# Altium schematic sheet 04_SMPS.SchDoc(04_SMPS)
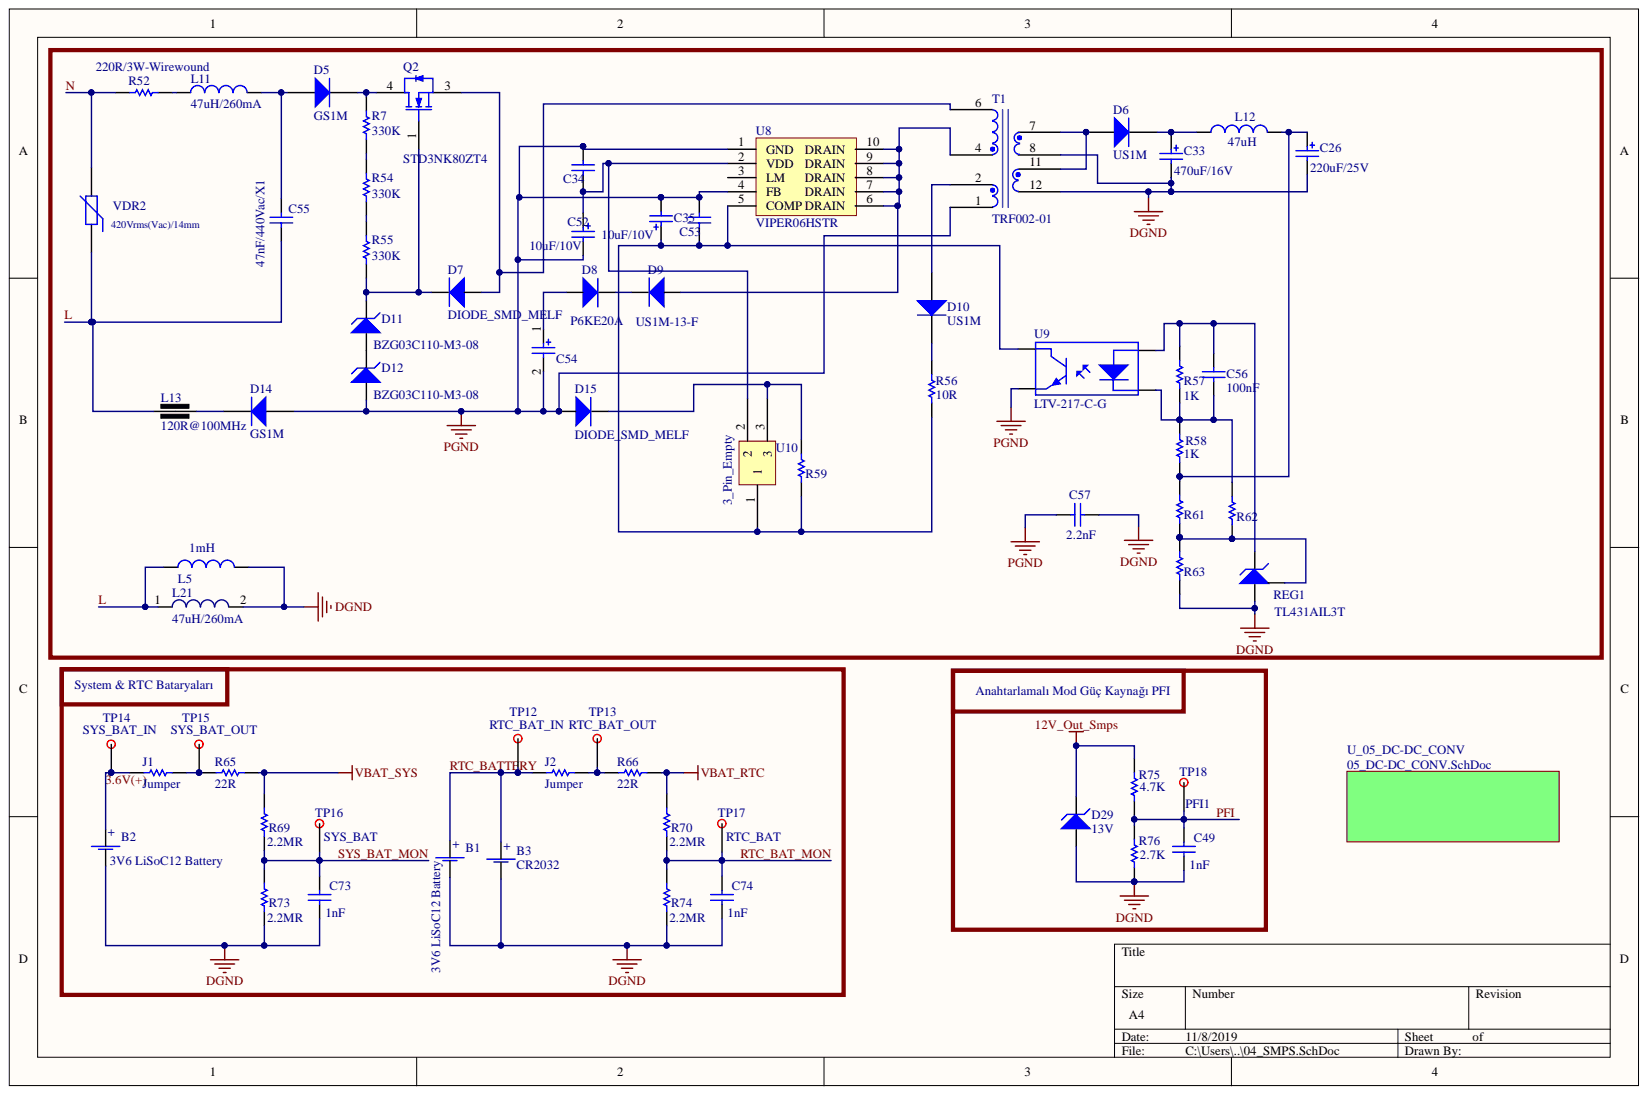
[diagram p0: 04_SMPS.SchDoc]

## Parts
B1  3V6 LiSoC12 Battery  [BATTERY-3V6-01-ER14250]
B2  3V6 LiSoC12 Battery  [BATTERY-3V6-01-ER14250]
B3  CR2032  [BATTERY-3V-02-CR2032]
C26  004_Value: 220uF/25V  (hidden comment: CAP_ELEC_THT)
C33  004_Value: 470uF/16V  (hidden comment: CAP_ELEC_THT)
C34  CAP_SMD  (value not drawn)
C35  004_Value: 10uF/10V  (hidden comment: CAP_ELEC_THT)
C49  Capacitance: 1nF  [CAP_0603_V1]  (hidden comment: CAP_SMD)
C52  004_Value: 10uF/10V  (hidden comment: CAP_ELEC_THT)
C53  CAP_SMD  (value not drawn)
C54  CAP_ELEC_THT  [CAP_ALU_ELC_RADIAL_D10_H20_P1-05.0_P2-05.0]  (value not drawn)
C55  value 47nF/440Vac/X1  [CAP_THT_MKP_P=15MM_AXIAL_RADIAL _7x13.5x17.5]  (hidden comment: -)
C56  Capacitance: 100nF  (hidden comment: CAP_SMD)
C57  Capacitance: 2.2nF  [CAPY5U]  (hidden comment: Safety Capacitor)
C73  Capacitance: 1nF  [CAP_0603_V1]  (hidden comment: CAP_SMD)
C74  Capacitance: 1nF  [CAP_0603_V1]  (hidden comment: CAP_SMD)
D5  value GS1M  [DIOSMA]  (hidden comment: DIODE)
D6  value US1M  [SOD-123]  (hidden comment: DIODE)
D7  DIODE_SMD_MELF  [SOD80C (MINIMELF)]
D8  017_Manufacturer-1 PN 1: P6KE20A  (hidden comment: DIODE_THT)
D9  DIODE_SMD  017_Manufacturer-1 PN 1: US1M-13-F
D10  value US1M  [SOD-123]  (hidden comment: DIODE)
D11  017_Manufacturer-1 PN 1: BZG03C110-M3-08  (hidden comment: DIODE_ZENER)
D12  017_Manufacturer-1 PN 1: BZG03C110-M3-08  (hidden comment: DIODE_ZENER)
D14  GS1M  [DO-214AC(SMA)]
D15  DIODE_SMD_MELF  [SOD80C (MINIMELF)]
D29  13V  [SOD123 - SOD323]
J1  008_Package: Jumper  [JUMPER_0603_V1]  (hidden comment: JUMPER_SOLDER_PCB)
J2  008_Package: Jumper  [JUMPER_0603_V1]  (hidden comment: JUMPER_SOLDER_PCB)
L5  Inductance: 1mH  [INDUCTOR_THT_RADIAL_P=9MM]  (hidden comment: INDUCTOR_THT)
L11  value 47uH/260mA  [INDUCTOR_SMD_1812(4532)]  (hidden comment: INDUCTOR_SMD)
L12  Inductance: 47uH  [INDUCTOR_SMD_1812(4532)]  (hidden comment: INDUCTOR_SMD)
L13  004_Value: 120R@100MHz  [FERRITE-BEAD_1206_V1]  (hidden comment: FERRITE BEAD)
L21  47uH/260mA  [IND_1812]
Q2  STD3NK80ZT4  [FQD3N60CTM_WS_DPAK]
R7  Resistance: 330K  [RES_1206_V1]  (hidden comment: RES_SMD)
R52  value 220R/3W-Wirewound  [RESRADIALP250MIL]  (hidden comment: RES_THT)
R54  Resistance: 330K  [RES_1206_V1]  (hidden comment: RES_SMD)
R55  Resistance: 330K  [RES_1206_V1]  (hidden comment: RES_SMD)
R56  value 10R  [RES_1206_V1]  (hidden comment: RES_SMD)
R57  Resistance: 1K  [RES_1206_V1]  (hidden comment: RES_SMD)
R58  Resistance: 1K  [RES_1206_V1]  (hidden comment: RES_SMD)
R59  RES_SMD  (value not drawn)
R61  RES_SMD  (value not drawn)
R62  RES_SMD  (value not drawn)
R63  RES_SMD  (value not drawn)
R65  Resistance: 22R  [RES_0603_V1]  (hidden comment: RES_SMD)
R66  Resistance: 22R  [RES_0603_V1]  (hidden comment: RES_SMD)
R69  Resistance: 2.2MR  [RES_0603_V1]  (hidden comment: RES_SMD)
R70  Resistance: 2.2MR  [RES_0603_V1]  (hidden comment: RES_SMD)
R73  Resistance: 2.2MR  [RES_0603_V1]  (hidden comment: RES_SMD)
R74  Resistance: 2.2MR  [RES_0603_V1]  (hidden comment: RES_SMD)
R75  004_Value: 4.7K  [RES_0603_V1]  (hidden comment: RES_SMD)
R76  004_Value: 2.7K  [RES_0603_V1]  (hidden comment: RES_SMD)
REG1  TL431AIL3T  [SOT-23]
T1  TRF002-01  [EF16_86]
TP12  004_Value: RTC_BAT_IN  [TEST-PAD_2.0X2.0_ROUND - No_3D_Step]  (hidden comment: TEST_POINT)
TP13  004_Value: RTC_BAT_OUT  [TEST-PAD_2.0X2.0_ROUND - No_3D_Step]  (hidden comment: TEST_POINT)
TP14  004_Value: SYS_BAT_IN  [TEST-PAD_2.0X2.0_ROUND - No_3D_Step]  (hidden comment: TEST_POINT)
TP15  004_Value: SYS_BAT_OUT  [TEST-PAD_2.0X2.0_ROUND - No_3D_Step]  (hidden comment: TEST_POINT)
TP16  004_Value: SYS_BAT  [TEST-PAD_2.0X2.0_ROUND - No_3D_Step]  (hidden comment: TEST_POINT)
TP17  004_Value: RTC_BAT  [TEST-PAD_2.0X2.0_ROUND - No_3D_Step]  (hidden comment: TEST_POINT)
TP18  004_Value: PFI1  [TEST-PAD_2.0X2.0_ROUND - No_3D_Step]  (hidden comment: TEST_POINT)
U8  VIPER06HSTR
U9  LTV-217-C-G  [LTV217_SOIC127P680X220-4N]
U10  3_Pin_Empty
VDR2  value 420Vrms(Vac)/14mm  [VARISTOR_14MM_V1]  (hidden comment: VARISTOR)

## Nets
12V_Out_Smps: D29.2 R75.2
DGND: B1.2 B2.2 B3.2 C26.2 C33.2 C49.1 C57.2 C73.1 C74.1 D29.1 L5.2 L21.2 R63.1 R73.1 R74.1 R76.1 REG1.3 T1.8 T1.12
PGND: C34.2 C35.2 C52.2 C53.2 C54.2 C57.1 D12.1 D14.1 D15.1 T1.1 U8.1(GND) U8.4(FB) U9.3
VBAT_RTC: R66.2 R70.2
VBAT_SYS: R65.2 R69.2
3.6V(+): B2.1 J1.1 TP14.1
L: C55.1 L5.1 L13.1 L21.1 VDR2.1
N: R52.2 VDR2.2
PFI: C49.2 R75.1 R76.2 TP18.1
RTC_BAT_MON: C74.2 R70.1 R74.2 TP17.1
RTC_BATTERY: B1.1 B3.1 J2.1 TP12.1
SYS_BAT_MON: C73.2 R69.1 R73.2 TP16.1
NetC26_1: C26.1 L12.2 R58.1 R61.2
NetC33_1: C33.1 D6.2 L12.1
NetC34_1: C34.1 C52.1 U8.2(VDD) U10.2
NetC35_1: C35.1 C53.1 R56.1 R59.1 U8.5(COMP) U9.4 U10.1
NetC54_1: C54.1 D8.1
NetC55_2: C55.2 D5.1 L11.2
NetC56_1: C56.1 R57.1 R58.2 R62.2 U9.2
NetC56_2: C56.2 R57.2 REG1.1 U9.1
NetD5_2: D5.2 Q2.4 R7.2
NetD6_1: D6.1 T1.7 T1.11
NetD7_1: D7.1 Q2.3 T1.6
NetD7_2: D7.2 D11.2 Q2.1 R55.1
NetD8_2: D8.2 D9.2
NetD9_1: D9.1 T1.4 U8.6(DRAIN) U8.7(DRAIN) U8.8(DRAIN) U8.9(DRAIN) U8.10(DRAIN)
NetD10_1: D10.1 T1.2
NetD10_2: D10.2 R56.2
NetD11_1: D11.1 D12.2
NetD14_2: D14.2 L13.2
NetD15_2: D15.2 R59.2 U10.3
NetJ1_2: J1.2 R65.1 TP15.1
NetJ2_2: J2.2 R66.1 TP13.1
NetL11_1: L11.1 R52.1
NetR7_1: R7.1 R54.2
NetR54_1: R54.1 R55.2
NetR61_1: R61.1 R62.1 R63.2 REG1.2

Not connected: U8 3(LM)

## Library data of the parts
B1 = Battery_3V6_LiSoC12: Battery, Single Cell, 3.6 V, 1/2AA, Lithium Thionyl Chloride, 1.2 Ah; 017_Manufacturer-1 PN: ER14250H; 016_Manufacturer-1: EVE Energy; Outside Diameter: 14.5mm; Capacity: 1.2ah; 001_Description: EVE         ER14250             Battery, Single Cell, Lithium Thionyl Chloride, 1.2 Ah, 3.6 V, 1/2AA; Weight: 10g; Supplier Part Number 1: 1365935; Supplier 1: Farnell; Stock 1: 0; REACH SVHC: No SVHC; Library Name: ElectricMeter.SchLib; Height: 25.4mm; Component Kind: Standard; Chemistry/Technology: Lithium
B2 = Battery_3V6_LiSoC12: 017_Manufacturer-1 PN: ER14250H; 016_Manufacturer-1: EVE Energy; Library Name: ElectricMeter.SchLib; Component Kind: Standard
B3 = CR2032_Battery3V: Battery, Li-Mn02 Coin, CR2032; 017_Manufacturer-1 PN: CR2032 - 3Pin – Vertical; 016_Manufacturer-1: EVE Energy; 004_Value: 3V / CR2032; Library Name: ElectricMeter.SchLib; Component Kind: Standard; 001_Designation: Battery
C49 C73 C74 = CAP_SMD: 017_Manufacturer-1 PN: CL10B102KB8SFNC; 016_Manufacturer-1: Samsung; Voltage Rating: 50V; Tolerance: 10%; Parameter Name: Tape and Reel; Dielectric: X7R; 006_SMT/THT: Surface Mount; 001_Description: CAP CER 1000PF 50V X7R 0603; Width: 0.031inch; Thickness: 0.035inch; Supplier Part Number 1: CL10B102KB8SFNC; Supplier 1: NAC Semi; Stock 1: 0; Radiation Hardening: No; Package Quantity: 4000; Min Operating Temperature: -55°C; Max Operating Temperature: 125°C; Library Name: ElectricMeter.SchLib; Length: 1.6mm; Lead Free: Lead Free; Height: 0.8mm; Features: Soft Termination; Depth: 0.8mm; ComponentLink1URL: https://media.digikey.com/pdf/Data%20Sheets/Samsung%20PDFs/CL_Series_MLCC_ds.pdf?src-supplier=Digi-Key; ComponentLink1Description: https://media.digikey.com/pdf/Data%20Sheets/Samsung%20PDFs/CL_Series_MLCC_ds.pdf?src-supplier=Digi-Key; Component Kind: Standard; Case Code (Metric): 1608; Case Code (Imperial): 0603; Case/Package: 0603
C55 = CAP_THT: Capacitor, Film Capacitor, THT, Metallized Polypropylene, 47nF, 440Vac, Class X1, %20, Pitch=15mm; 017_Manufacturer-1 PN: BFC233817473; 016_Manufacturer-1: VISHAY; Model 4: BM32; Model 3: BM31; Model 2: BM22; Model 1: BM21; Status: MP; SMT/THT: THT; Designation: Capacitor; Component Kind: Standard; Library Name: HK_SCH_BAYLAN.SchLib
C56 = CAP_SMD: CAP CER 0.1UF 50V X7R 0603; 017_Manufacturer-1 PN 1: CL10B104KB8SFNC; 016_Manufacturer-1 1: Samsung; Tolerance: 10%; Width: 0.031inch; Voltage Rating: 50V; Thickness: 0.035inch; Supplier Part Number 1: 1276-1936-1-ND; Supplier 1: Digi-Key; Stock 1: 924204; RoHS: Yes; Radiation Hardening: No; Pricing 1: 1=0.10000, 10=0.02900, 100=0.01300, 500=0.00928, 1000=0.00729 (USD); Packaging: Tape and Reel; Package Quantity: 4000; Mount: Surface Mount; Min Operating Temperature: -55°C; Max Operating Temperature: 125°C; Library Name: ElectricMeter.SchLib; Length: 1.6mm; Lead Free: Lead Free; Height: 0.8mm; Features: Soft Termination; Dielectric: X7R; Depth: 0.8mm; Contact Plating: Tin,Matte; ComponentLink1URL: https://datasheet.ciiva.com/25714/cl10b104kb8sfnc-25714514.pdf?src-supplier=Digi-Key; ComponentLink1Description: https://datasheet.ciiva.com/25714/cl10b104kb8sfnc-25714514.pdf?src-supplier=Digi-Key; Component Kind: Standard; Case Code (Metric): 1608; Case Code (Imperial): 0603; Case/Package: 0603
C57 = VY1222M47Y5UQ63V0: CAP CER 2200PF 760VAC Y5U RADIAL; 048_Manufacturer-2 PN: VY2222M35Y5US63V7; 047_Manufacturer-2: Vishay; 016_Manufacturer-1: Vishay BCcomponents; Voltage Rating (DC): 500V; Tolerance: 20%; Parameter Name: Bulk; Lead Length: 30mm; Lead Diameter: 0.6mm; Lead/Base Style: Kinked; 006_SMT/THT: Through Hole,PCB; Width: 5mm; Voltage Rating (AC): 500V; Thickness: 5mm; Termination: Radial; Supplier Part Number 1: VY1222M47Y5UQ63V0; Supplier 1: Perfect Parts; Stock 1: 0; REACH SVHC: Unknown; Radiation Hardening: No; Package Quantity: 1000; Number of Pins: 2; Min Operating Temperature: -40°C; Max Operating Temperature: 125°C; Library Name: ElectricMeter.SchLib; Lead Pitch: 10mm; Lead Free: Lead Free; Height - Seated (Max): 0.63inch; Dielectric: Y5U; Depth: 5mm; Contact Plating: Tin; Composition: Ceramic; ComponentLink6URL: https://www.verical.com/server-webapp/html/getDatasheet?partID=402941&src-supplier=Verical; ComponentLink6Description: https://www.verical.com/server-webapp/html/getDatasheet?partID=402941&src-supplier=Verical; ComponentLink5URL: http://www.vishay.com/docs/28536/geninfo-cd-rfi-safcaps.pdf?src-supplier=Digi-Key; ComponentLink5Description: http://www.vishay.com/docs/28536/geninfo-cd-rfi-safcaps.pdf?src-supplier=Digi-Key; ComponentLink4URL: https://www.alliedelec.com/m/d/73e785ccf075f142b1356f4b3424b368.pdf?src-supplier=Allied+Electronics; ComponentLink4Description: https://www.alliedelec.com/m/d/73e785ccf075f142b1356f4b3424b368.pdf?src-supplier=Allied+Electronics; ComponentLink3URL: http://www.farnell.com/datasheets/2049413.pdf?src-supplier=Element14; ComponentLink3Description: http://www.farnell.com/datasheets/2049413.pdf?src-supplier=Element14; ComponentLink2URL: https://www.alliedelec.com/m/d/a74e4a713e6b7feab5b502db7b5403ec.pdf?src-supplier=Allied+Electronics; ComponentLink2Description: https://www.alliedelec.com/m/d/a74e4a713e6b7feab5b502db7b5403ec.pdf?src-supplier=Allied+Electronics; ComponentLink1URL: http://www.vishay.com/docs/28537/vy1series.pdf?src-supplier=Future+Electronics; ComponentLink1Description: http://www.vishay.com/docs/28537/vy1series.pdf?src-supplier=Future+Electronics; Component Kind: Standard; Case/Package: Radial; 017_Manufacturer-1 PN: VY1222M47Y5UQ63V0; 001_Description: CAP CER 2200PF 760VAC Y5U RADIAL
D5 = DIODE_2: Diode, Rectifier, 1000V, 1A, GS1M; Manufacturer PN: M7; Manufacturer: PANJIT; SMT/THT: SMT; Model 4: BM32; Model 3: BM31; Model 2: BM22; Model 1: BM21; Status: MP; Manufacturer PN 2: -; Manufacturer 2: -; Library Name: BM_COMM_180705.IntLib; Designation: Diode; Component Kind: Standard
D6 D10 = DIODE_1: Diode, 1000V, 1A, trr<100nS; Manufacturer PN: US1M-13-F; Manufacturer: DIODES; SMT/THT: SMT; Model 4: BM32; Model 3: BM31; Model 2: BM22; Model 1: BM21; Status: MP; Manufacturer PN 2: -; Manufacturer 2: -; Library Name: BM_COMM_180705.IntLib; Designation: Diode; Component Kind: Standard
D7 D15 = DIODE_SMD: Library Name: ElectricMeter.SchLib; Component Kind: Standard
D8 = DIODE_THT: 016_Manufacturer-1 1: ON Semiconductor; Voltage Rating (DC): 20V; Test Current: 1mA; Reverse Standoff Voltage: 17.1V; Reverse Breakdown Voltage: 19V; Power Line Protection: No; Power Dissipation: 5W; Polarity: Unidirectional; Peak Pulse Power: 600W; Peak Pulse Current: 22A; Number of Unidirectional Channels: 1; Max Reverse Leakage Current: 5uA; Max Breakdown Voltage: 21V; Element Configuration: Single; Clamping Voltage: 27.7V; Capacitance: 1.6pF; Width: 3.56mm; Weight: 0.3g; Termination: Axial; Supplier Part Number 1: 5438602; Supplier 1: RSComponents; Stock 1: 3175; REACH SVHC: No SVHC; Radiation Hardening: No; Packaging: Tape and Reel; Package Quantity: 1000; Operating Supply Voltage: 17.1V; Number of Pins: 2; Number of Elements: 1; Number of Channels: 1; Mount: Through Hole; Min Operating Temperature: -65°C; Min Breakdown Voltage: 19V; Max Surge Current: 22A; Max Power Dissipation: 600W; Max Operating Temperature: 175°C; Library Name: ElectricMeter.SchLib; Length: 7.62mm; Leakage Current: 5uA; Lead Free: Lead Free; Height: 3.56mm; Direction: Unidirectional; Diameter: 3.56mm; Composition: Zener; ComponentLink21URL: http://datasheet.octopart.com/P6KE20A-Fairchild-Semiconductor-datasheet-77554621.pdf?src-supplier=Element14; ComponentLink21Description: http://datasheet.octopart.com/P6KE20A-Fairchild-Semiconductor-datasheet-77554621.pdf?src-supplier=Element14; ComponentLink20URL: http://datasheet.octopart.com/P6KE20A-Fairchild-Semiconductor-datasheet-68340767.pdf?src-supplier=Element14; ComponentLink20Description: http://datasheet.octopart.com/P6KE20A-Fairchild-Semiconductor-datasheet-68340767.pdf?src-supplier=Element14; ComponentLink19URL: http://datasheet.octopart.com/P6KE20A-Fairchild-Semiconductor-datasheet-67809955.pdf?src-supplier=Newark; ComponentLink19Description: http://datasheet.octopart.com/P6KE20A-Fairchild-Semiconductor-datasheet-67809955.pdf?src-supplier=Newark; ComponentLink18URL: http://sigma.octopart.com/17343680/technical_drawing/Fairchild-Semiconductor-P6KE20A.pdf?src-supplier=Fairchild+Semiconductor; ComponentLink18Description: http://sigma.octopart.com/17343680/technical_drawing/Fairchild-Semiconductor-P6KE20A.pdf?src-supplier=Fairchild+Semiconductor; ComponentLink17URL: http://datasheet.octopart.com/P6KE20A-Fairchild-Semiconductor-datasheet-7537324.pdf?src-supplier=Farnell; ComponentLink17Description: http://datasheet.octopart.com/P6KE20A-Fairchild-Semiconductor-datasheet-7537324.pdf?src-supplier=Farnell; ComponentLink16URL: http://datasheet.octopart.com/P6KE20A-ON-Semiconductor-datasheet-15335.pdf?src-supplier=Digi-Key; ComponentLink16Description: http://datasheet.octopart.com/P6KE20A-ON-Semiconductor-datasheet-15335.pdf?src-supplier=Digi-Key; ComponentLink15URL: http://datasheet.octopart.com/P6KE20A-Fairchild-Semiconductor-datasheet-11524524.pdf?src-supplier=_legacy+Avnet; ComponentLink15Description: http://datasheet.octopart.com/P6KE20A-Fairchild-Semiconductor-datasheet-11524524.pdf?src-supplier=_legacy+Avnet; ComponentLink14URL: http://datasheet.octopart.com/P6KE20A-Fairchild-datasheet-3040.pdf?src-supplier=Digi-Key; ComponentLink14Description: http://datasheet.octopart.com/P6KE20A-Fairchild-datasheet-3040.pdf?src-supplier=Digi-Key; ComponentLink13URL: http://datasheet.octopart.com/P6KE20A-ON-Semiconductor-datasheet-86692788.pdf?src-supplier=Farnell; ComponentLink13Description: http://datasheet.octopart.com/P6KE20A-ON-Semiconductor-datasheet-86692788.pdf?src-supplier=Farnell; ComponentLink12URL: http://datasheet.octopart.com/P6KE20A-Fairchild-Semiconductor-datasheet-16207774.pdf?src-supplier=Fairchild+Semiconductor; ComponentLink12Description: http://datasheet.octopart.com/P6KE20A-Fairchild-Semiconductor-datasheet-16207774.pdf?src-supplier=Fairchild+Semiconductor; ComponentLink11URL: http://datasheet.octopart.com/P6KE20A-Fairchild-Semiconductor-datasheet-501951.pdf?src-supplier=Element14; ComponentLink11Description: http://datasheet.octopart.com/P6KE20A-Fairchild-Semiconductor-datasheet-501951.pdf?src-supplier=Element14; ComponentLink10URL: http://datasheet.octopart.com/P6KE20A-ON-Semiconductor-datasheet-109986027.pdf?src-supplier=ON+Semiconductor; ComponentLink10Description: http://datasheet.octopart.com/P6KE20A-ON-Semiconductor-datasheet-109986027.pdf?src-supplier=ON+Semiconductor; ComponentLink9URL: http://datasheet.octopart.com/P6KE20A-ON-Semiconductor-datasheet-5320614.pdf?src-supplier=ON+Semiconductor; ComponentLink9Description: http://datasheet.octopart.com/P6KE20A-ON-Semiconductor-datasheet-5320614.pdf?src-supplier=ON+Semiconductor; ComponentLink8URL: http://datasheet.octopart.com/P6KE20A-ON-Semiconductor-datasheet-8361193.pdf?src-supplier=ON+Semiconductor; ComponentLink8Description: http://datasheet.octopart.com/P6KE20A-ON-Semiconductor-datasheet-8361193.pdf?src-supplier=ON+Semiconductor; ComponentLink7URL: https://datasheet.ciiva.com/29588/p6ke100a-29588511.pdf?src-supplier=Digi-Key; ComponentLink7Description: https://datasheet.ciiva.com/29588/p6ke100a-29588511.pdf?src-supplier=Digi-Key; ComponentLink6URL: https://datasheet.ciiva.com/29588/p6ke100a-29588510.pdf?src-supplier=Digi-Key; ComponentLink6Description: https://datasheet.ciiva.com/29588/p6ke100a-29588510.pdf?src-supplier=Digi-Key; ComponentLink5URL: https://datasheet.ciiva.com/8199/p6ke9v1ca-8199208.pdf?src-supplier=Avnet; ComponentLink5Description: https://datasheet.ciiva.com/8199/p6ke9v1ca-8199208.pdf?src-supplier=Avnet; ComponentLink4URL: https://datasheet.ciiva.com/7974/1725637-7974134.pdf?src-supplier=Element14; ComponentLink4Description: https://datasheet.ciiva.com/7974/1725637-7974134.pdf?src-supplier=Element14; ComponentLink3URL: https://datasheet.ciiva.com/7974/1725637-7974133.pdf?src-supplier=Element14; ComponentLink3Description: https://datasheet.ciiva.com/7974/1725637-7974133.pdf?src-supplier=Element14; ComponentLink2URL: https://datasheet.ciiva.com/360/p6ke6-8a-d-360256.pdf?src-supplier=Digi-Key; ComponentLink2Description: https://datasheet.ciiva.com/360/p6ke6-8a-d-360256.pdf?src-supplier=Digi-Key; ComponentLink1URL: https://datasheet.ciiva.com/4508/p6ke20a-191524-4508130.pdf?src-supplier=Mouser; ComponentLink1Description: https://datasheet.ciiva.com/4508/p6ke20a-191524-4508130.pdf?src-supplier=Mouser; Component Kind: Standard; Case/Package: DO-15; Breakdown Voltage: 19V
D9 = DIODE_SMD: 016_Manufacturer-1 1: Diodes Inc.; Voltage Rating (DC): 1kV; Reverse Recovery Time: 75ns; Polarity: Standard; Peak Reverse Current: 5uA; Peak Non-Repetitive Surge Current: 30A; Output Current: 1A; Forward Voltage: 1.7V; Element Configuration: Single; Capacitance: 10pF; Width: 2.92mm; Weight: 0.002258oz; Termination: SMD/SMT; Supplier Part Number 1: US1M-FDICT-ND; Supplier 1: Digi-Key; Stock 1: 427154; Reverse Voltage (DC): 1kV; Recovery Time: 75ns; REACH SVHC: No SVHC; Radiation Hardening: No; Pricing 1: 1=0.42000, 10=0.31700, 100=0.19760, 500=0.13520, 1000=0.10400 (USD); Packaging: Tape and Reel; Package Quantity: 5000; Number of Pins: 2; Mount: Surface Mount; Min Operating Temperature: -65°C; Max Surge Current: 30A; Max Reverse Voltage (DC): 1kV; Max Repetitive Reverse Voltage (Vrrm): 1kV; Max Operating Temperature: 150°C; Max Forward Surge Current (Ifsm): 30A; Library Name: ElectricMeter.SchLib; Length: 4.6mm; Lead Free: Lead Free; Height: 2.1mm; Forward Current: 1A; Current Rating: 1A; Contact Plating: Tin; ComponentLink15URL: http://datasheet.octopart.com/US1M-13-F-Multicomp-datasheet-21399542.pdf?src-supplier=Newark; ComponentLink15Description: http://datasheet.octopart.com/US1M-13-F-Multicomp-datasheet-21399542.pdf?src-supplier=Newark; ComponentLink14URL: http://datasheet.octopart.com/US1M-13-F-Diodes-Inc.-datasheet-110025916.pdf?src-supplier=TME; ComponentLink14Description: http://datasheet.octopart.com/US1M-13-F-Diodes-Inc.-datasheet-110025916.pdf?src-supplier=TME; ComponentLink13URL: http://datasheet.octopart.com/US1M-13-F-Diodes-Inc.-datasheet-14053627.pdf?src-supplier=IIIC; ComponentLink13Description: http://datasheet.octopart.com/US1M-13-F-Diodes-Inc.-datasheet-14053627.pdf?src-supplier=IIIC; ComponentLink12URL: http://datasheet.octopart.com/US1M-13-F-Diodes-Inc.-datasheet-8199251.pdf?src-supplier=Jameco; ComponentLink12Description: http://datasheet.octopart.com/US1M-13-F-Diodes-Inc.-datasheet-8199251.pdf?src-supplier=Jameco; ComponentLink11URL: http://datasheet.octopart.com/US1M-13-F-Diodes-Inc.-datasheet-28448.pdf?src-supplier=Mouser; ComponentLink11Description: http://datasheet.octopart.com/US1M-13-F-Diodes-Inc.-datasheet-28448.pdf?src-supplier=Mouser; ComponentLink10URL: http://datasheet.octopart.com/US1M-13-F-Diodes-Inc.-datasheet-41192569.pdf?src-supplier=Allied+Electronics; ComponentLink10Description: http://datasheet.octopart.com/US1M-13-F-Diodes-Inc.-datasheet-41192569.pdf?src-supplier=Allied+Electronics; ComponentLink9URL: http://datasheet.octopart.com/US1M-13-F-Diodes-Inc.-datasheet-8325229.pdf?src-supplier=Element14; ComponentLink9Description: http://datasheet.octopart.com/US1M-13-F-Diodes-Inc.-datasheet-8325229.pdf?src-supplier=Element14; ComponentLink8URL: http://datasheet.octopart.com/US1M-13-F-Diodes-Inc.-datasheet-11778825.pdf?src-supplier=Element14; ComponentLink8Description: http://datasheet.octopart.com/US1M-13-F-Diodes-Inc.-datasheet-11778825.pdf?src-supplier=Element14; ComponentLink7URL: https://datasheet.ciiva.com/8303/ds16008-8303103.pdf?src-supplier=Avnet; ComponentLink7Description: https://datasheet.ciiva.com/8303/ds16008-8303103.pdf?src-supplier=Avnet; ComponentLink6URL: https://datasheet.ciiva.com/8301/ds16008-8301519.pdf?src-supplier=Avnet; ComponentLink6Description: https://datasheet.ciiva.com/8301/ds16008-8301519.pdf?src-supplier=Avnet; ComponentLink5URL: https://datasheet.ciiva.com/22695/1906610-22695853.pdf?src-supplier=Element14; ComponentLink5Description: https://datasheet.ciiva.com/22695/1906610-22695853.pdf?src-supplier=Element14; ComponentLink4URL: https://datasheet.ciiva.com/917/ds16008-917196.pdf?src-supplier=Digi-Key; ComponentLink4Description: https://datasheet.ciiva.com/917/ds16008-917196.pdf?src-supplier=Digi-Key; ComponentLink3URL: https://datasheet.ciiva.com/917/ds16008-917195.pdf?src-supplier=Digi-Key; ComponentLink3Description: https://datasheet.ciiva.com/917/ds16008-917195.pdf?src-supplier=Digi-Key; ComponentLink2URL: https://datasheet.ciiva.com/27052/1542696-27052440.pdf?src-supplier=Jameco; ComponentLink2Description: https://datasheet.ciiva.com/27052/1542696-27052440.pdf?src-supplier=Jameco; ComponentLink1URL: https://datasheet.ciiva.com/4454/ds16008-60440-4454096.pdf?src-supplier=Mouser; ComponentLink1Description: https://datasheet.ciiva.com/4454/ds16008-60440-4454096.pdf?src-supplier=Mouser; Component Kind: Standard; Case/Package: SMA; Average Rectified Current: 1A
D11 D12 = DIODE_ZENER: 016_Manufacturer-1 1: Vishay; Supplier Part Number 1: BZG03C110-M3-08GICT-ND; Supplier 1: Digi-Key; Stock 1: 2937; Pricing 1: 1=0.50000, 10=0.42500, 25=0.39640, 100=0.31720, 250=0.29460, 500=0.24926 (USD); Library Name: ElectricMeter.SchLib; ComponentLink1URL: http://datasheet.octopart.com/BZG03C110-M3-08-Vishay-datasheet-113094172.pdf?src-supplier=Future+Electronics; ComponentLink1Description: http://datasheet.octopart.com/BZG03C110-M3-08-Vishay-datasheet-113094172.pdf?src-supplier=Future+Electronics; Component Kind: Standard
D14 = GS1M: Diode, Surface Mount Rectifier, 1000V, 1A, SMA/DO-214AC; 017_Manufacturer-1 PN: GS1M-TR/_R2_00001; 016_Manufacturer-1: Panjit; Supplier Link: https://www.ozdisan.com/guc-yari-iletkenleri/diyot-modul-diyot-dogrultucular/genel-amacli-diyotlar/GS1M-TRR200001?gclid=CjwKCAjwm-fkBRBBEiwA966fZMwlaso3FtcDdQhPQWcfQ-g1nDLv9kAg53vYL6tb9e4-Ki5-JCcXhxoCiakQAvD_BwE; Library Name: ElectricMeter.SchLib; Datasheet: https://img.ozdisan.com/ETicaret_Dosya/457952_6509309.pdf; Component Kind: Standard; 004_Value: GS1M; 001_Designation: Diode
D29 = DIODE_ZENER: 017_Manufacturer-1 PN: MMSZ4700-V_R2_00001; 016_Manufacturer-1: Pan Jit; Max Reverse Leakage Current: 50nA; Max Power Dissipation: 500mW; Element Configuration: Single; 006_SMT/THT: Surface Mount; 001_Description: Diode Zener Single 13V 5% 500mW 2-Pin SOD-123 T/R; Zener Voltage: 13V; Supplier Part Number 1: MMSZ4700-V_ R2 _00001; Supplier 1: Avnet; Stock 1: 0; Radiation Hardening: No; Number of Pins: 2; Min Operating Temperature: -55°C; Max Operating Temperature: 150°C; Library Name: ElectricMeter.SchLib; Component Kind: Standard
J1 J2 = JUMPER_SOLDER_PCB: Solder Jumper; 005_Process: Manuel_Solder; Library Name: ElectricMeter.SchLib; Component Kind: Standard; 007_Status: Jumper; 006_SMT/THT: Jumper; 004_Value: Jumper; 001_Designation: Jumper
L5 = INDUCTOR_THT: 017_Manufacturer-1 PN: RFB0810-102L; 016_Manufacturer-1: Coilcraft; Saturation Current: 430mA; RMS Current (Irms): 500mA; Resistance: 2.2R; Inductance Tolerance: 10%; 001_Description: COILCRAFT         RFB0810-102L             INDUCTOR, POWER, 1MH, 0.43A, 10%; Supplier Part Number 1: RFB0810-102L; Supplier 1: Quest; Stock 1: 120; REACH SVHC: No SVHC; Library Name: ElectricMeter.SchLib; DC Resistance (DCR): 2.2R; Component Kind: Standard
L11 = INDUCTOR_SMD: Inductor, SMD1812, 47uH, 260mA; Manufacturer PN: CC453232-470KL; Manufacturer: Bourns Inc.; SMT/THT: SMT; Model 4: BM32; Model 3: BM31; Model 2: BM22; Model 1: BM21; Status: MP; Manufacturer PN 2: -; Manufacturer 2: -; Library Name: BM_COMM_180705.IntLib; Designation: Inductor; Component Kind: Standard
L12 = INDUCTOR_SMD: 017_Manufacturer-1 PN: CC453232-470KL; 016_Manufacturer-1: Bourns; Tolerance: 10%; Test Frequency: 2.52MHz; Shielding: Unshielded; Self Resonant Frequency: 11.5MHz; Q Factor: 10; Parameter Name: Tape and Reel; Max DC Current: 260mA; DC Resistance (DCR): 1.9R; Current: 140mA; Core Material: Ferrite; 006_SMT/THT: Surface Mount; 001_Description: Fixed Inductors 47uH 10% 2.52MHz; Width: 0.126inch; Termination: SMD/SMT; Supplier Part Number 1: CC453232-470KL; Supplier 1: Perfect Parts; Stock 1: 0; Package Quantity: 500; Min Operating Temperature: -40°C; Military Standard: Not; Max Operating Temperature: 125°C; Library Name: ElectricMeter.SchLib; Length: 0.177inch; Height - Seated (Max): 0.134inch; Height: 3.2mm; Depth: 3.4mm; DC Current: 260mA; Current Rating: 260mA; Composition: Wirewound; ComponentLink3URL: http://www.bourns.com/pdfs/CC453232.pdf?src-supplier=Avnet; ComponentLink3Description: http://www.bourns.com/pdfs/CC453232.pdf?src-supplier=Avnet; ComponentLink2URL: http://www.bourns.com/pdfs/CC453232.pdf?src-supplier=Avnet+Europe; ComponentLink2Description: http://www.bourns.com/pdfs/CC453232.pdf?src-supplier=Avnet+Europe; ComponentLink1URL: http://www.bourns.com/docs/Product-Datasheets/CC453232.pdf?src-supplier=Digi-Key; ComponentLink1Description: http://www.bourns.com/docs/Product-Datasheets/CC453232.pdf?src-supplier=Digi-Key; Component Kind: Standard; Case Code (Metric): 4532; Case Code (Imperial): 1812; Case/Package: 1812
L13 = FERRITE BEAD: Inductor, Ferrite Bead, 120R@100Mhz, Power Line, 2A; 019_Manufacturer-1 Digi-Key PN: 1276-6366-2-ND; 017_Manufacturer-1 PN: CIC31P121NE; 016_Manufacturer-1: SAMSUNG; 008_Package: L1206; 007_Status: MP; 006_SMT/THT: SMT; 005_Process: SMD_Mounter; Library Name: ElectricMeter.SchLib; Component Kind: Standard; 003_Remark: -; 001_Designation: Ferrite Bead
L21 = CC453232-470KL: Fixed Inductors 47uH 10% 2.52MHz; 016_Manufacturer-1: Bourns; Shielding: Unshielded; Self Resonant Frequency: 11.5MHz; Q Factor: 10; Parameter Name: Tape and Reel; Max DC Current: 260mA; DC Resistance (DCR): 1.9R; Current: 140mA; Core Material: Ferrite; Width: 0.126inch; Tolerance: 10%; Test Frequency: 2.52MHz; Termination: SMD/SMT; Supplier Part Number 1: CC453232-470KL; Supplier 1: Perfect Parts; Stock 1: 0; Package Quantity: 500; Mount: Surface Mount; Min Operating Temperature: -40°C; Military Standard: Not; Max Operating Temperature: 125°C; Library Name: ElectricMeter.SchLib; Length: 0.177inch; Inductance: 47uH; Height - Seated (Max): 0.134inch; Height: 3.2mm; Depth: 3.4mm; DC Current: 260mA; Current Rating: 260mA; Composition: Wirewound; ComponentLink3URL: http://www.bourns.com/pdfs/CC453232.pdf?src-supplier=Avnet; ComponentLink3Description: http://www.bourns.com/pdfs/CC453232.pdf?src-supplier=Avnet; ComponentLink2URL: http://www.bourns.com/pdfs/CC453232.pdf?src-supplier=Avnet+Europe; ComponentLink2Description: http://www.bourns.com/pdfs/CC453232.pdf?src-supplier=Avnet+Europe; ComponentLink1URL: http://www.bourns.com/docs/Product-Datasheets/CC453232.pdf?src-supplier=Digi-Key; ComponentLink1Description: http://www.bourns.com/docs/Product-Datasheets/CC453232.pdf?src-supplier=Digi-Key; Component Kind: Standard; Case Code (Metric): 4532; Case Code (Imperial): 1812; Case/Package: 1812; 017_Manufacturer-1 PN: CC453232-470KL; 001_Description: Fixed Inductors 47uH 10% 2.52MHz
Q2 = STD3NK80ZT4: N-Channel 800V 2.5A (Tc) 70W (Tc) Surface Mount DPAK; 016_Manufacturer-1: STMicroelectronics; Turn-On Delay Time: 17ns; Turn-Off Delay Time: 36ns; Threshold Voltage: 3.75V; Rise Time: 27ns; Rds On Max: 4.5R; Parameter Name: Tape and Reel; Number of Elements: 1; Input Capacitance: 485pF; Gate to Source Voltage (Vgs): 30V; Fall Time: 40ns; Element Configuration: Single; 001_Description: Trans MOSFET N-CH 800V 2.5A 3-Pin(2+Tab) DPAK T/R; Width: 6.2mm; Voltage Rating (DC): 800V; Supplier Part Number 1: STD3NK80ZT4; Supplier 1: Verical; Stock 1: 0; REACH SVHC: No SVHC; Radiation Hardening: No; Power Dissipation: 70W; Package Quantity: 2500; On-State Resistance: 4.5R; Number of Pins: 3; Min Operating Temperature: -55°C; Max Power Dissipation: 70W; Max Operating Temperature: 150°C; Library Name: ElectricMeter.SchLib; Length: 6.6mm; Lead Free: Lead Free; Height: 2.4mm; Drain to Source Voltage (Vdss): 800V; Drain to Source Resistance: 4.5R; Drain to Source Breakdown Voltage: 800V; Current Rating: 2.5A; Continuous Drain Current (ID): 2.5A; ComponentLink7URL: http://www.st.com/content/ccc/resource/technical/document/datasheet/2f/d2/8c/46/49/6b/47/bf/CD00003377.pdf/files/CD00003377.pdf/jcr:content/translations/en.CD00003377.pdf?src-supplier=Digi-Key; ComponentLink7Description: http://www.st.com/content/ccc/resource/technical/document/datasheet/2f/d2/8c/46/49/6b/47/bf/CD00003377.pdf/files/CD00003377.pdf/jcr:content/translations/en.CD00003377.pdf?src-supplier=Digi-Key; ComponentLink6URL: http://www.farnell.com/datasheets/1689953.pdf?src-supplier=Element14; ComponentLink6Description: http://www.farnell.com/datasheets/1689953.pdf?src-supplier=Element14; ComponentLink5URL: http://www.st.com/web/en/resource/technical/document/datasheet/CD00003377.pdf?src-supplier=Future+Electronics; ComponentLink5Description: http://www.st.com/web/en/resource/technical/document/datasheet/CD00003377.pdf?src-supplier=Future+Electronics; ComponentLink4URL: http://www.mccsemi.com/up_pdf/DFLZ6V2%20-%20DFLZ39(SOD-123FL).pdf?src-supplier=Digi-Key; ComponentLink4Description: http://www.mccsemi.com/up_pdf/DFLZ6V2%20-%20DFLZ39(SOD-123FL).pdf?src-supplier=Digi-Key; ComponentLink3URL: http://www.mouser.com/ds/2/149/FQD3N60CTM_WS-111760.pdf?src-supplier=Mouser; ComponentLink3Description: http://www.mouser.com/ds/2/149/FQD3N60CTM_WS-111760.pdf?src-supplier=Mouser; ComponentLink2URL: https://www.fairchildsemi.com/datasheets/FQ/FQD3N60CTM_WS.pdf?src-supplier=Digi-Key; ComponentLink2Description: https://www.fairchildsemi.com/datasheets/FQ/FQD3N60CTM_WS.pdf?src-supplier=Digi-Key; ComponentLink1URL: http://www.fairchildsemi.com/ds/FQ/FQD3N60CTM_WS.pdf?src-supplier=Future+Electronics; ComponentLink1Description: http://www.fairchildsemi.com/ds/FQ/FQD3N60CTM_WS.pdf?src-supplier=Future+Electronics; Component Kind: Standard; Case/Package: DPAK; 017_Manufacturer-1 PN: STD3NK80ZT4; 006_SMT/THT: Surface Mount
R7 R54 R55 = RES_SMD: 017_Manufacturer-1 PN: RC1206FR-07330KL; 016_Manufacturer-1: Yageo; Voltage Rating (DC): 200V; Tolerance: 1%; Temperature Coefficient: 100ppm/°C; Power Rating: 250mW; Width: 1.6mm; Voltage Rating: 200V; Termination: SMD/SMT; Supplier Part Number 1: 311-330KFRCT-ND; Supplier 1: Digi-Key; Stock 1: 1151589; REACH SVHC: Unknown; Pricing 1: 1=0.10000, 10=0.07100, 100=0.02910, 1000=0.01306, 2500=0.01134 (USD); Packaging: Tape and Reel; Number of Pins: 2; Min Operating Temperature: -55°C; Military Standard: Not; Max Operating Temperature: 155°C; Library Name: ElectricMeter.SchLib; Length: 3.1mm; Lead Free: Lead Free; Height: 0.55mm; Depth: 1.6mm; Composition: Thick Film; ComponentLink11URL: http://datasheet.octopart.com/RC1206FR-07330KL-Yageo-datasheet-13032847.pdf?src-supplier=Future+Electronics; ComponentLink11Description: http://datasheet.octopart.com/RC1206FR-07330KL-Yageo-datasheet-13032847.pdf?src-supplier=Future+Electronics; ComponentLink10URL: http://datasheet.octopart.com/RC1206FR-07330KL-Yageo-datasheet-118639.pdf?src-supplier=Digi-Key; ComponentLink10Description: http://datasheet.octopart.com/RC1206FR-07330KL-Yageo-datasheet-118639.pdf?src-supplier=Digi-Key; ComponentLink9URL: http://datasheet.octopart.com/RC1206FR-07330KL-Yageo-datasheet-73766026.pdf; ComponentLink9Description: http://datasheet.octopart.com/RC1206FR-07330KL-Yageo-datasheet-73766026.pdf; ComponentLink8URL: http://datasheet.octopart.com/RC1206FR-07330KL-Yageo-datasheet-27233761.pdf?src-supplier=Future+Electronics; ComponentLink8Description: http://datasheet.octopart.com/RC1206FR-07330KL-Yageo-datasheet-27233761.pdf?src-supplier=Future+Electronics; ComponentLink7URL: http://datasheet.octopart.com/RC1206FR-07330KL-Yageo-datasheet-62067350.pdf?src-supplier=Farnell; ComponentLink7Description: http://datasheet.octopart.com/RC1206FR-07330KL-Yageo-datasheet-62067350.pdf?src-supplier=Farnell; ComponentLink6URL: http://datasheet.octopart.com/RC1206FR-07330KL-Yageo-datasheet-8359522.pdf?src-supplier=Element14; ComponentLink6Description: http://datasheet.octopart.com/RC1206FR-07330KL-Yageo-datasheet-8359522.pdf?src-supplier=Element14; ComponentLink5URL: http://datasheet.octopart.com/RC1206FR-07330KL-Yageo-datasheet-127823281.pdf?src-supplier=Future+Electronics; ComponentLink5Description: http://datasheet.octopart.com/RC1206FR-07330KL-Yageo-datasheet-127823281.pdf?src-supplier=Future+Electronics; ComponentLink4URL: https://datasheet.ciiva.com/7857/444357-7857327.pdf?src-supplier=Element14; ComponentLink4Description: https://datasheet.ciiva.com/7857/444357-7857327.pdf?src-supplier=Element14; ComponentLink3URL: https://datasheet.ciiva.com/5990/1686128-5990172.pdf?src-supplier=Farnell; ComponentLink3Description: https://datasheet.ciiva.com/5990/1686128-5990172.pdf?src-supplier=Farnell; ComponentLink2URL: https://datasheet.ciiva.com/16746/pyu-rc1206-51-rohs-l-4-16746987.pdf?src-supplier=Future+Electronics; ComponentLink2Description: https://datasheet.ciiva.com/16746/pyu-rc1206-51-rohs-l-4-16746987.pdf?src-supplier=Future+Electronics; ComponentLink1URL: https://datasheet.ciiva.com/11809/0900766b80c6630a-11809517.pdf?src-supplier=RSComponents; ComponentLink1Description: https://datasheet.ciiva.com/11809/0900766b80c6630a-11809517.pdf?src-supplier=RSComponents; Component Kind: Standard; Case Code (Metric): 3216; Case Code (Imperial): 1206; Case/Package: 1206
R52 = RES_THT: Resistor, Wirewound, 220R, 3W, Flame Retardant Coating, Pulse Withstanding; 017_Manufacturer-1 PN: EP3W220RJ; 016_Manufacturer-1: TE Connectivity; SMT/THT: THT; Model 4: BM32; Model 3: BM31; Model 2: BM22; Model 1: BM21; Designation: Wirewound Resistor; Status: MP; Manufacturer PN 2: -; Manufacturer 2: -; Component Kind: Standard; Library Name: HK_SCH_BAYLAN.SchLib
R56 = RES_SMD: Chip Resistor,10R, %1, 0603; Manufacturer PN: RC1206FR-0710RL; Manufacturer: Yageo; SMT/THT: SMT; Model 4: BM32; Model 3: BM31; Model 2: BM22; Model 1: BM21; Status: MP; Manufacturer PN 2: -; Manufacturer 2: -; Library Name: BM_COMM_180705.IntLib; Designation: Resistor; Component Kind: Standard
R57 R58 = RES_SMD: RES SMD 1K OHM 1% 1/4W 1206; 017_Manufacturer-1 PN 1: RC1206FR-071KL; 016_Manufacturer-1 1: Yageo; Voltage Rating: 200V; Temperature Coefficient: 100ppm/°C; Power Rating: 250mW; Tolerance: 1%; Termination: SMD/SMT; Supplier Part Number 1: 311-1.00KFRCT-ND; Supplier 1: Digi-Key; Stock 1: 10716170; RoHS: Yes; REACH SVHC: Unknown; Pricing 1: 1=0.10000, 10=0.07100, 100=0.02910, 1000=0.01306, 2500=0.01134 (USD); Packaging: Tape and Reel; Min Operating Temperature: -55°C; Military Standard: Not; Max Operating Temperature: 155°C; Library Name: ElectricMeter.SchLib; Length: 3.1mm; Lead Free: Lead Free; Height: 0.55mm; Depth: 1.6mm; Composition: Thick Film; ComponentLink3URL: https://datasheet.ciiva.com/46445/444357-46445708.pdf?src-supplier=Element14; ComponentLink3Description: https://datasheet.ciiva.com/46445/444357-46445708.pdf?src-supplier=Element14; ComponentLink2URL: https://datasheet.ciiva.com/29181/402540-da-01-en-smd-widerstand-chip1206-1-kohm-29181462.pdf?src-supplier=Conrad; ComponentLink2Description: https://datasheet.ciiva.com/29181/402540-da-01-en-smd-widerstand-chip1206-1-kohm-29181462.pdf?src-supplier=Conrad; ComponentLink1URL: https://datasheet.ciiva.com/11808/0900766b80c6630a-11808217.pdf?src-supplier=RSComponents; ComponentLink1Description: https://datasheet.ciiva.com/11808/0900766b80c6630a-11808217.pdf?src-supplier=RSComponents; Component Kind: Standard; Case Code (Metric): 3216; Case Code (Imperial): 1206; Case/Package: 1206
R65 R66 = RES_SMD: 017_Manufacturer-1 PN: RC0603FR-0722RL; 016_Manufacturer-1: Yageo; Voltage Rating (DC): 50V; Tolerance: 1%; Temperature Coefficient: 100ppm/°C; Power Rating: 100mW; Parameter Name: Tape and Reel; 001_Description: RES SMD 22 OHM 1% 1/10W 0603; Width: 0.031inch; Voltage Rating: 50V; Termination: SMD/SMT; Supplier Part Number 1: RC0603FR-07 22RL; Supplier 1: Verical; Stock 1: 0; REACH SVHC: No SVHC; Package Quantity: 1; Number of Terminations: 2; Number of Pins: 2; Min Operating Temperature: -55°C; Military Standard: Not; Max Power Dissipation: 100mW; Max Operating Temperature: 155°C; Library Name: ElectricMeter.SchLib; Length: 1.6mm; Lead Free: Lead Free; Height: 0.022inch; Features: Moisture Resistant; Depth: 0.8mm; Composition: Thick Film; ComponentLink4URL: http://www.farnell.com/datasheets/443971.pdf?src-supplier=Element14; ComponentLink4Description: http://www.farnell.com/datasheets/443971.pdf?src-supplier=Element14; ComponentLink3URL: http://www.farnell.com/datasheets/1868787.pdf?src-supplier=Element14; ComponentLink3Description: http://www.farnell.com/datasheets/1868787.pdf?src-supplier=Element14; ComponentLink2URL: http://documents.rs-components.com/techlib://itc_doclist_GB.htm?stockno=5050369&src-supplier=RSComponents; ComponentLink2Description: http://documents.rs-components.com/techlib://itc_doclist_GB.htm?stockno=5050369&src-supplier=RSComponents; ComponentLink1URL: http://www.yageo.com/documents/recent/PYu-RC_Group_51_RoHS_L_10.pdf?src-supplier=Digi-Key; ComponentLink1Description: http://www.yageo.com/documents/recent/PYu-RC_Group_51_RoHS_L_10.pdf?src-supplier=Digi-Key; Component Kind: Standard; Case Code (Metric): 1608; Case Code (Imperial): 0603; Case/Package: 0603
R69 R70 R73 R74 = RES_SMD: 017_Manufacturer-1 PN: RC0603FR-072M2L; 016_Manufacturer-1: Yageo; Voltage Rating (DC): 50V; Tolerance: 1%; Temperature Coefficient: 100ppm/°C; Power Rating: 100mW; Parameter Name: Tape and Reel; 001_Description: YAGEO - RC0603FR-072M2L - RES, 2M2, 1%, 0.1W, 0603, THICK FILM; Width: 0.8mm; Voltage Rating: 50V; Termination: SMD/SMT; Supplier Part Number 1: 505-0347; Supplier 1: RSComponents; Stock 1: 0; REACH SVHC: No SVHC; Package Quantity: 5000; Number of Terminations: 2; Number of Pins: 2; Min Operating Temperature: -55°C; Max Power Dissipation: 100mW; Max Operating Temperature: 155°C; Library Name: ElectricMeter.SchLib; Length: 1.6mm; Lead Free: Lead Free; Height: 0.45mm; Features: Moisture Resistant; Depth: 0.8mm; Composition: Thick Film; ComponentLink4URL: http://www.farnell.com/datasheets/443971.pdf?src-supplier=Element14; ComponentLink4Description: http://www.farnell.com/datasheets/443971.pdf?src-supplier=Element14; ComponentLink3URL: http://www.farnell.com/datasheets/1868787.pdf?src-supplier=Element14; ComponentLink3Description: http://www.farnell.com/datasheets/1868787.pdf?src-supplier=Element14; ComponentLink2URL: http://www.mouser.com/catalog/specsheets/RC0603.pdf?src-supplier=Mouser; ComponentLink2Description: http://www.mouser.com/catalog/specsheets/RC0603.pdf?src-supplier=Mouser; ComponentLink1URL: http://documents.rs-components.com/techlib://itc_doclist_GB.htm?stockno=5050347&src-supplier=RSComponents; ComponentLink1Description: http://documents.rs-components.com/techlib://itc_doclist_GB.htm?stockno=5050347&src-supplier=RSComponents; Component Kind: Standard; Case Code (Metric): 1608; Case Code (Imperial): 0603; Case/Package: 0603
R75 = RES_SMD: RES.(1608) 0603 1.4K Ohms 1% 1/10W-S 100PPM; 017_Manufacturer-1 PN: 0603SAF4701T5E; 016_Manufacturer-1: Royal Electronic Factory; 001_Description: Resistor: thick film; SMD; 0603; 4.7kO; 0.1W; ±1%; -55÷125°C; Tolerance: 1%; Supplier Part Number 1: 0603SAF4701T5E; Supplier 1: TME; Stock 1: 177000; Pricing 1: 5000=0.00301, 50000=0.00215, 200000=0.002 (USD); Library Name: ElectricMeter.SchLib; ComponentLink4URL: http://www.yageo.com/documents/recent/PYu-RC_Group_51_RoHS_L_10.pdf?src-supplier=Digi-Key; ComponentLink4Description: http://www.yageo.com/documents/recent/PYu-RC_Group_51_RoHS_L_10.pdf?src-supplier=Digi-Key; ComponentLink3URL: http://www.yageo.com/documents/recent/PYu-RC_Group_51_RoHS_L_9.pdf?src-supplier=Digi-Key; ComponentLink3Description: http://www.yageo.com/documents/recent/PYu-RC_Group_51_RoHS_L_9.pdf?src-supplier=Digi-Key; ComponentLink2URL: http://www.mouser.com/catalog/specsheets/RC0603.pdf?src-supplier=Mouser; ComponentLink2Description: http://www.mouser.com/catalog/specsheets/RC0603.pdf?src-supplier=Mouser; ComponentLink1URL: http://documents.rs-components.com/techlib://itc_doclist_GB.htm?stockno=6167317&src-supplier=RSComponents; ComponentLink1Description: http://documents.rs-components.com/techlib://itc_doclist_GB.htm?stockno=6167317&src-supplier=RSComponents; Component Kind: Standard; Case/Package: 0603
R76 = RES_SMD: 017_Manufacturer-1 PN: 0603SAJ0272T5E; 016_Manufacturer-1: Royal Electronic Factory; Supplier Part Number 1: SMD0603-2K7; Supplier 1: TME; Stock 1: 7400; Pricing 1: 100=0.0119, 1000=0.0029, 5000=0.00135, 15000=0.00114, 50000=0.00104 (USD); Library Name: ElectricMeter.SchLib; Component Kind: Standard
REG1 = TL431AIL3T: IC VREF SHUNT ADJ SOT23-3; 016_Manufacturer-1: STMicroelectronics; Tolerance: 1%; Reference Voltage: 2.495V; Reference Type: Shunt,Adjustable; Output Voltage: 36V; Output Current: 100mA; Number of Channels: 1; Max Input Voltage: 37V; Cathode Current: 700uA; Supplier Part Number 1: 497-11295-1-ND; Supplier 1: Digi-Key; Stock 1: 9692; RoHS: Yes; Radiation Hardening: No; Pricing 1: 1=0.43000, 10=0.35100, 100=0.23930, 500=0.17948, 1000=0.13460 (USD); Packaging: Tape and Reel; Package Quantity: 1; Number of Pins: 3; Mount: Surface Mount; Min Operating Temperature: -40°C; Max Output Voltage: 36V; Max Output Current: 100mA; Max Operating Temperature: 105°C; Library Name: ElectricMeter.SchLib; Lead Free: Lead Free; ComponentLink6URL: https://datasheet.ciiva.com/2006/cd00000986-2006624.pdf?src-supplier=Digi-Key; ComponentLink6Description: https://datasheet.ciiva.com/2006/cd00000986-2006624.pdf?src-supplier=Digi-Key; ComponentLink5URL: https://datasheet.ciiva.com/4908/cd00000986-4908895.pdf?src-supplier=Mouser; ComponentLink5Description: https://datasheet.ciiva.com/4908/cd00000986-4908895.pdf?src-supplier=Mouser; ComponentLink4URL: https://datasheet.ciiva.com/17565/cd00000986-17565254.pdf?src-supplier=Avnet+Europe; ComponentLink4Description: https://datasheet.ciiva.com/17565/cd00000986-17565254.pdf?src-supplier=Avnet+Europe; ComponentLink3URL: https://datasheet.ciiva.com/2006/cd00000986-2006626.pdf?src-supplier=Digi-Key; ComponentLink3Description: https://datasheet.ciiva.com/2006/cd00000986-2006626.pdf?src-supplier=Digi-Key; ComponentLink2URL: https://datasheet.ciiva.com/2006/cd00000986-2006625.pdf?src-supplier=Digi-Key; ComponentLink2Description: https://datasheet.ciiva.com/2006/cd00000986-2006625.pdf?src-supplier=Digi-Key; ComponentLink1URL: https://datasheet.ciiva.com/41424/001184461-da-01-en-ic-vref-shunt-pr-tl431ail3t-sot-23-3-stm-41424435.pdf?src-supplier=Conrad; ComponentLink1Description: https://datasheet.ciiva.com/41424/001184461-da-01-en-ic-vref-shunt-pr-tl431ail3t-sot-23-3-stm-41424435.pdf?src-supplier=Conrad; Component Kind: Standard; Case/Package: SOT-23-3; Accuracy: 1%; 017_Manufacturer-1 PN: TL431AIL3T
T1 = TRF002-01: Flyback Transformer; 016_Manufacturer-1: Tianjin New Ventech; Library Name: ElectricMeter.SchLib; Component Kind: Standard; 017_Manufacturer-1 PN: TRF002-01
TP12-TP18 = TEST_POINT: Test Point, 2mm; 007_Status: TP; 006_SMT/THT: SMT; Library Name: ElectricMeter.SchLib; Component Kind: Standard; 008_Package: -; 005_Process: Test Point; 003_Remark: -; 001_Designation: Test Point
U8 = VIPER06HSTR: IC OFFLINE SWITCH PWM 10-SSOP; 016_Manufacturer-1 1: STMicroelectronics; Switching Frequency: 115kHz; Output Voltage: 800V; Output Power: 30mW; Operating Supply Current: 1.6mA; Number of Outputs: 1; Min Supply Voltage: 11.5V; Max Supply Voltage: 23.5V; Max Power Dissipation: 8W; Max Duty Cycle: 80%; Supplier Part Number 1: 497-13032-1-ND; Supplier 1: Digi-Key; Stock 1: 3780; Radiation Hardening: No; Pricing 1: 1=0.87000, 10=0.76500, 100=0.58630, 500=0.46350, 1000=0.37080 (USD); Packaging: Tape and Reel; Package Quantity: 1; Number of Pins: 10; Mount: Surface Mount; Min Operating Temperature: -40°C; Max Operating Temperature: 150°C; Library Name: ElectricMeter.SchLib; Length: 4.9mm; Lead Free: Lead Free; ComponentLink7URL: http://datasheet.octopart.com/VIPER06HSTR-STMicroelectronics-datasheet-12516301.pdf?src-supplier=STMicroelectronics; ComponentLink7Description: http://datasheet.octopart.com/VIPER06HSTR-STMicroelectronics-datasheet-12516301.pdf?src-supplier=STMicroelectronics; ComponentLink6URL: https://datasheet.ciiva.com/12073/dm00048250-12073247.pdf?src-supplier=Mouser; ComponentLink6Description: https://datasheet.ciiva.com/12073/dm00048250-12073247.pdf?src-supplier=Mouser; ComponentLink5URL: https://datasheet.ciiva.com/17564/dm00048250-17564444.pdf?src-supplier=Avnet+Europe; ComponentLink5Description: https://datasheet.ciiva.com/17564/dm00048250-17564444.pdf?src-supplier=Avnet+Europe; ComponentLink4URL: https://datasheet.ciiva.com/6613/dm00048250-6613356.pdf?src-supplier=Digi-Key; ComponentLink4Description: https://datasheet.ciiva.com/6613/dm00048250-6613356.pdf?src-supplier=Digi-Key; ComponentLink3URL: https://datasheet.ciiva.com/6613/dm00048250-6613354.pdf?src-supplier=Digi-Key; ComponentLink3Description: https://datasheet.ciiva.com/6613/dm00048250-6613354.pdf?src-supplier=Digi-Key; ComponentLink2URL: https://datasheet.ciiva.com/6613/dm00048250-6613353.pdf?src-supplier=Digi-Key; ComponentLink2Description: https://datasheet.ciiva.com/6613/dm00048250-6613353.pdf?src-supplier=Digi-Key; ComponentLink1URL: https://datasheet.ciiva.com/16287/dm00048250-16287188.pdf?src-supplier=Future+Electronics; ComponentLink1Description: https://datasheet.ciiva.com/16287/dm00048250-16287188.pdf?src-supplier=Future+Electronics; Component Kind: Standard; Case/Package: SOP; Breakdown Voltage: 800V; 017_Manufacturer-1 PN 1: VIPER06HSTR
U9 = LTV-217-C-G: OPTOISOLATOR 3.75KV TRANS 4-SSOP; 016_Manufacturer-1: Vishay Lite-On; Output Current per Channel: 50mA; Number of Channels: 1; Max Power Dissipation: 200mW; Max Output Voltage: 20V; Max Collector Current: 30mA; Collector Emitter Voltage (VCEO): 400mV; Supplier Part Number 1: 859-LTV217CG; Supplier 1: Mouser; Stock 1: 16314; Pricing 1: 1=0.62, 10=0.37, 50=0.37, 100=0.239, 1000=0.165, 10000=0.128 (USD); Package Quantity: 3000; Number of Pins: 4; Mount: Surface Mount; Min Operating Temperature: -40°C; Max Operating Temperature: 85°C; Max Input Current: 50mA; Library Name: ElectricMeter.SchLib; Lead Free: Lead Free; ComponentLink2URL: http://optoelectronics.liteon.com/upload/download/DS70-2009-0016/S_110_LTV-217-G%20Series%20Rev%20-%20July%2016%202012.pdf?src-supplier=Avnet; ComponentLink2Description: http://optoelectronics.liteon.com/upload/download/DS70-2009-0016/S_110_LTV-217-G%20Series%20Rev%20-%20July%2016%202012.pdf?src-supplier=Avnet; ComponentLink1URL: http://optoelectronics.liteon.com/upload/download/DS70-2009-0016/LTV-2X7%20sereis%20201610.pdf?src-supplier=Digi-Key; ComponentLink1Description: http://optoelectronics.liteon.com/upload/download/DS70-2009-0016/LTV-2X7%20sereis%20201610.pdf?src-supplier=Digi-Key; Component Kind: Standard; Collector Emitter Saturation Voltage: 400mV; Case/Package: SOIC; 017_Manufacturer-1 PN: LTV-217-C-G
VDR2 = VARISTOR: Varistor, 14mm, Vrms: 420Vac, Imax:6500A, Wmax:185 Joule; Manufacturer PN: V420LA20CP; Manufacturer: LITTELFUSE; SMT/THT: THT; Model 4: BM32; Model 3: BM31; Model 2: BM22; Model 1: BM21; Status: MP; Manufacturer PN 2: B72214P2421K101; Manufacturer 2: EPCOS/TDK; Designation: Varistor; Component Kind: Standard; Library Name: HK_SCH_BAYLAN.SchLib
C26 C33 C35 C52 C54 = CAP_ELEC_THT: Library Name: ElectricMeter.SchLib; Component Kind: Standard
C34 C53 = CAP_SMD: Library Name: ElectricMeter.SchLib; Component Kind: Standard
R59 R61-R63 = RES_SMD: Library Name: ElectricMeter.SchLib; Component Kind: Standard
U10 = 3_Pin_Empty: Library Name: ElectricMeter.SchLib; Component Kind: Standard
